# Altium schematic sheet USB.SchDoc
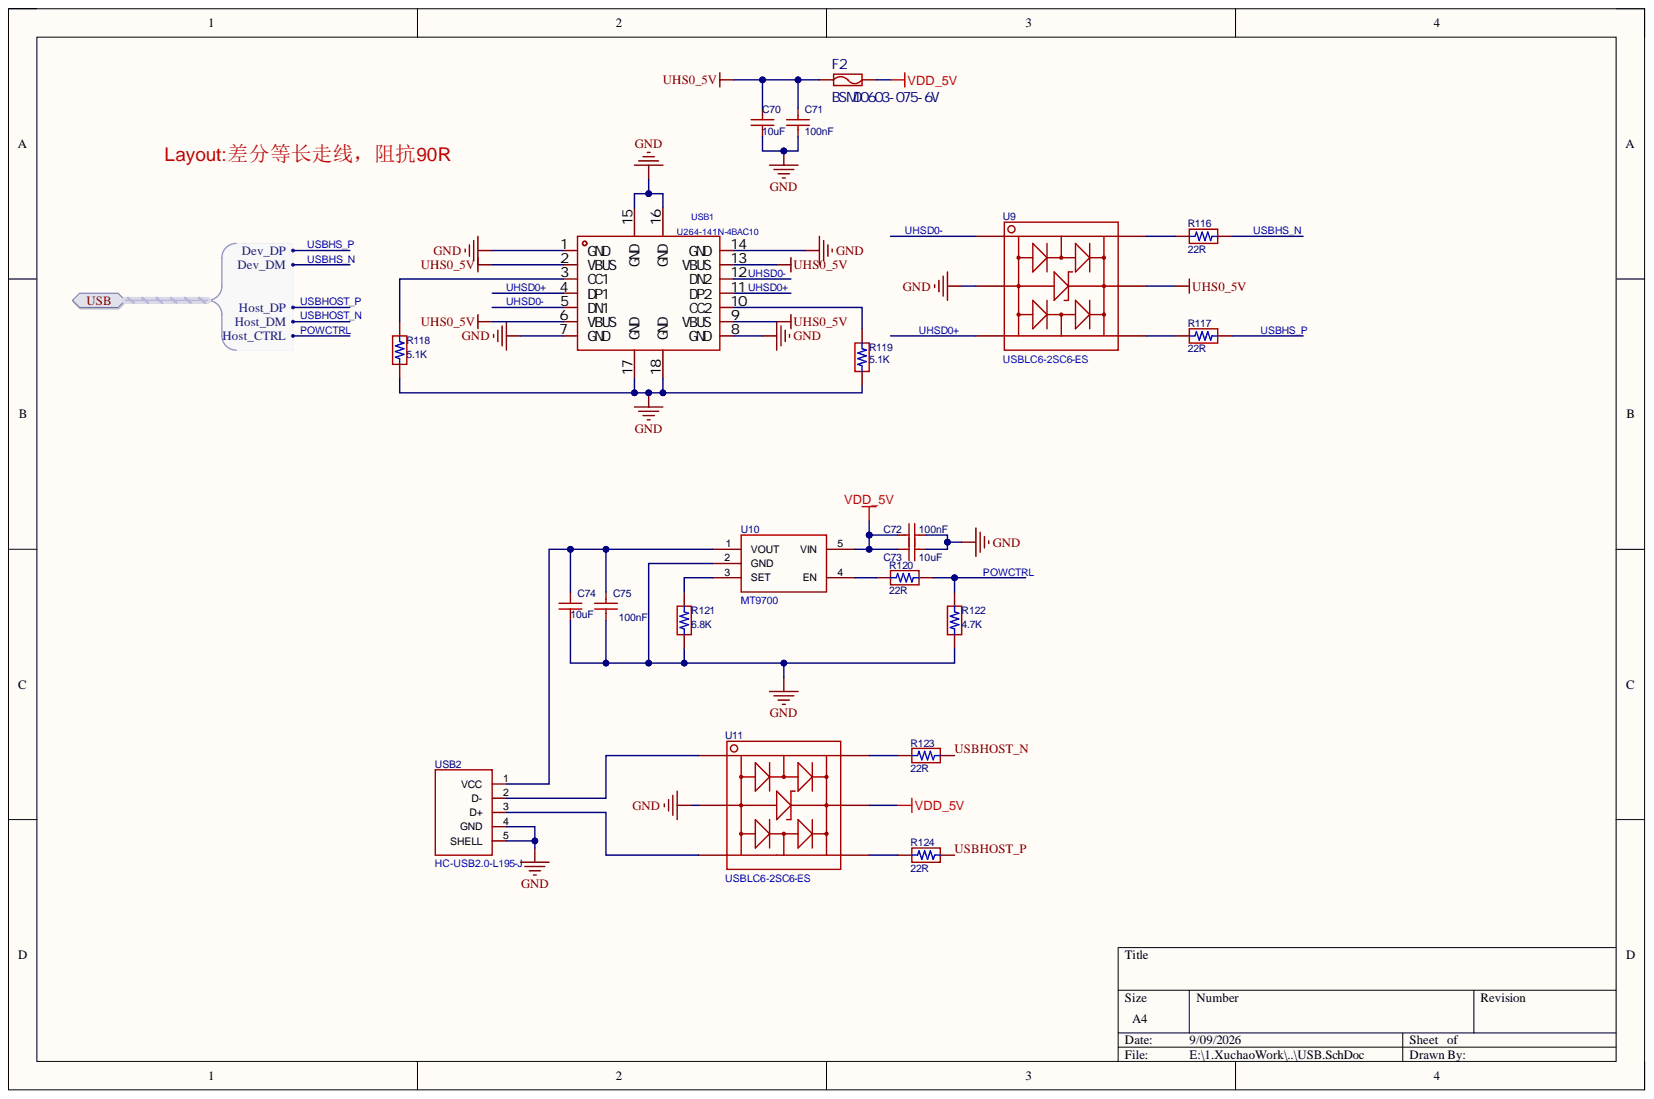
[diagram p0: USB.SchDoc]

## Parts
C70  10uF  [C0402]
C71  100nF  [C0402]
C72  100nF  [C0402]
C73  10uF  [C0402]
C74  10uF  [C0603]
C75  100nF  [C0402]
F2  BSMD0603-075-6V  [FUSE 0603]
R116  22R  [R0402]
R117  22R  [R0402]
R118  5.1K  [R0402]
R119  5.1K  [R0402]
R120  22R  [R0402]
R121  6.8K  [R0402]
R122  4.7K  [R0402]
R123  22R  [R0402]
R124  22R  [R0402]
U9  USBLC6-2SC6-ES  [SOT-23-6_L2.9-W1.6-P0.95-LS2.8-BR]
U10  MT9700  [SOT-23-5_L3.0-W1.7-P0.95-LS2.8-BR]
U11  USBLC6-2SC6-ES  [SOT-23-6_L2.9-W1.6-P0.95-LS2.8-BR]
USB1  U264-141N-4BAC10  [USB-C-TH_U264-141N-4BAC10]
USB2  HC-USB2.0-L195-J  [USB-A-TH_FC-USB-AF-24]

## Ports
USB, USB.Dev_DM, USB.Dev_DP, USB.Host_CTRL, USB.Host_DM, USB.Host_DP

## Nets
GND: C70.1 C71.1 C72.1 C73.1 C74.1 C75.1 R118.1 R119.1 R121.1 R122.1 U9.2 U10.2(GND) U11.2 USB1.1(GND) USB1.7(GND) USB1.8(GND) USB1.14(GND) USB1.15(GND) USB1.16(GND) USB1.17(GND) USB1.18(GND) USB2.4(GND) USB2.5(SHELL)
UHS0_5V: C70.2 C71.2 F2.1 U9.5 USB1.2(VBUS) USB1.6(VBUS) USB1.9(VBUS) USB1.13(VBUS)
VDD_5V: C72.2 C73.2 F2.2 U10.5(VIN) U11.5
POWCTRL: R120.1 R122.2 ; port USB
UHSD0+: U9.3 USB1.4(DP1) USB1.11(DP2)
UHSD0-: U9.1 USB1.5(DN1) USB1.12(DN2)
USBHOST_N: R123.1 ; port USB
USBHOST_P: R124.1 ; port USB
USBHS_N: R116.1 ; port USB
USBHS_P: R117.1 ; port USB
NetC74_2: C74.2 C75.2 U10.1(VOUT) USB2.1(VCC)
NetR116_2: R116.2 U9.6
NetR117_2: R117.2 U9.4
NetR118_2: R118.2 USB1.3(CC1)
NetR119_2: R119.2 USB1.10(CC2)
NetR120_2: R120.2 U10.4(EN)
NetR121_2: R121.2 U10.3(SET)
NetR123_2: R123.2 U11.6
NetR124_2: R124.2 U11.4
NetU11_1: U11.1 USB2.2(D-)
NetU11_3: U11.3 USB2.3(D+)

## Library data of the parts
C70-C75 = 0SMD_CAP: Symbol: CL10A106KP8NNNC; Component Kind: Standard; Library Name: CYKJ_LibPkg.IntLib
F2 = FUSE_BSMD0603-075-6V: 最大电压:6V;保持电流:750mA;最大电流:40A;消耗功率:500mW;工作温度:-40~+85;跳闸电流:1.5A;初始态阻值(最小值):60mΩ;最大动作时间:100ms;跳断后阻值(最大值):450mΩ;; Manufacturer: BHFUSE(佰宏); Add into BOM: yes; Current Rating (Max): 40A; Hold Current: 750mA; Maximum Time to Trip: 100ms; Operating Voltage (Max): 6V; Power Dissipation: 500mW; Resistance - Initial (Ri) (Min): 60mΩ; Resistance - Post Trip (R1) (Max): 450mΩ; Trip Current: 1.5A; Component Kind: Standard; Convert to PCB: yes; Datasheet: https://atta.szlcsc.com/upload/public/pdf/source/20210323/C914092_7D34F11EFDEF5808278D8DFC5DDABC72.pdf; Device: BSMD0603-075-6V; JLCPCB Part Class: Extended Part; LCSC Part Name: 0603 0.75A 6V; Manufacturer Part: BSMD0603-075-6V; Operating Temperature: -40~+85; Supplier: LCSC; Supplier Footprint: 0603; Supplier Part: C914092; Symbol: BSMD0603-075-6V; Library Name: CYKJ_LibPkg.IntLib
R116-R124 = 0SMD_RES: Component Kind: Standard; Library Name: CYKJ_LibPkg.IntLib
U9 U11 = TVS_USBLC6-2SC6-ES: 2路单向+带VBUS防护ESD静电防护器件，20kV，CJ：0.6pF；HDMI/USB; Component Kind: Standard; Symbol: USBLC6-2SC6-ES; Library Name: CYKJ_LibPkg.IntLib
U10 = IC_POWER_SWITCH_MT9700: Component Kind: Standard; Symbol: MT9700; Library Name: CYKJ_LibPkg.IntLib
USB1 = USB_TYPEC_U264-141N-4BAC10: type-c 母座 14P 竖插; Manufacturer: XKB Connection(中国星坤); Add into BOM: yes; Current Rating - Power (Max): 3A; Gender: 母; Number of Ports: 1; Soldering Temperature(Max): 235℃; Component Kind: Standard; Connector Type: Type-C; Contact Material: 铜合金; Contact Plating: 金; Convert to PCB: yes; Datasheet: https://atta.szlcsc.com/upload/public/pdf/source/20211011/3C526B1D157DB714A375BD92771BEA39.pdf; Device: U264-141N-4BAC10; JLCPCB Part Class: Extended Part; LCSC Part Name: Type-C 母; Manufacturer Part: U264-141N-4BAC10; Mounting Style: -; Number of Contacts: 14P; Operating Temperature Range: -40℃~+85℃; PCB3D: USB-C-TH_U264-141N-4BAC10; Standard: -; Supplier: LCSC; Supplier Footprint: 插件; Supplier Part: C692526; Symbol: U264-141N-4BAC10; Library Name: CYKJ_LibPkg.IntLib
USB2 = USB_2_0_TYPEA: USB2.0 TYPEA; Symbol: MINI 5PTP; Component Kind: Standard; Library Name: CYKJ_LibPkg.IntLib
